# Altium schematic sheet Sheet1.SchDoc
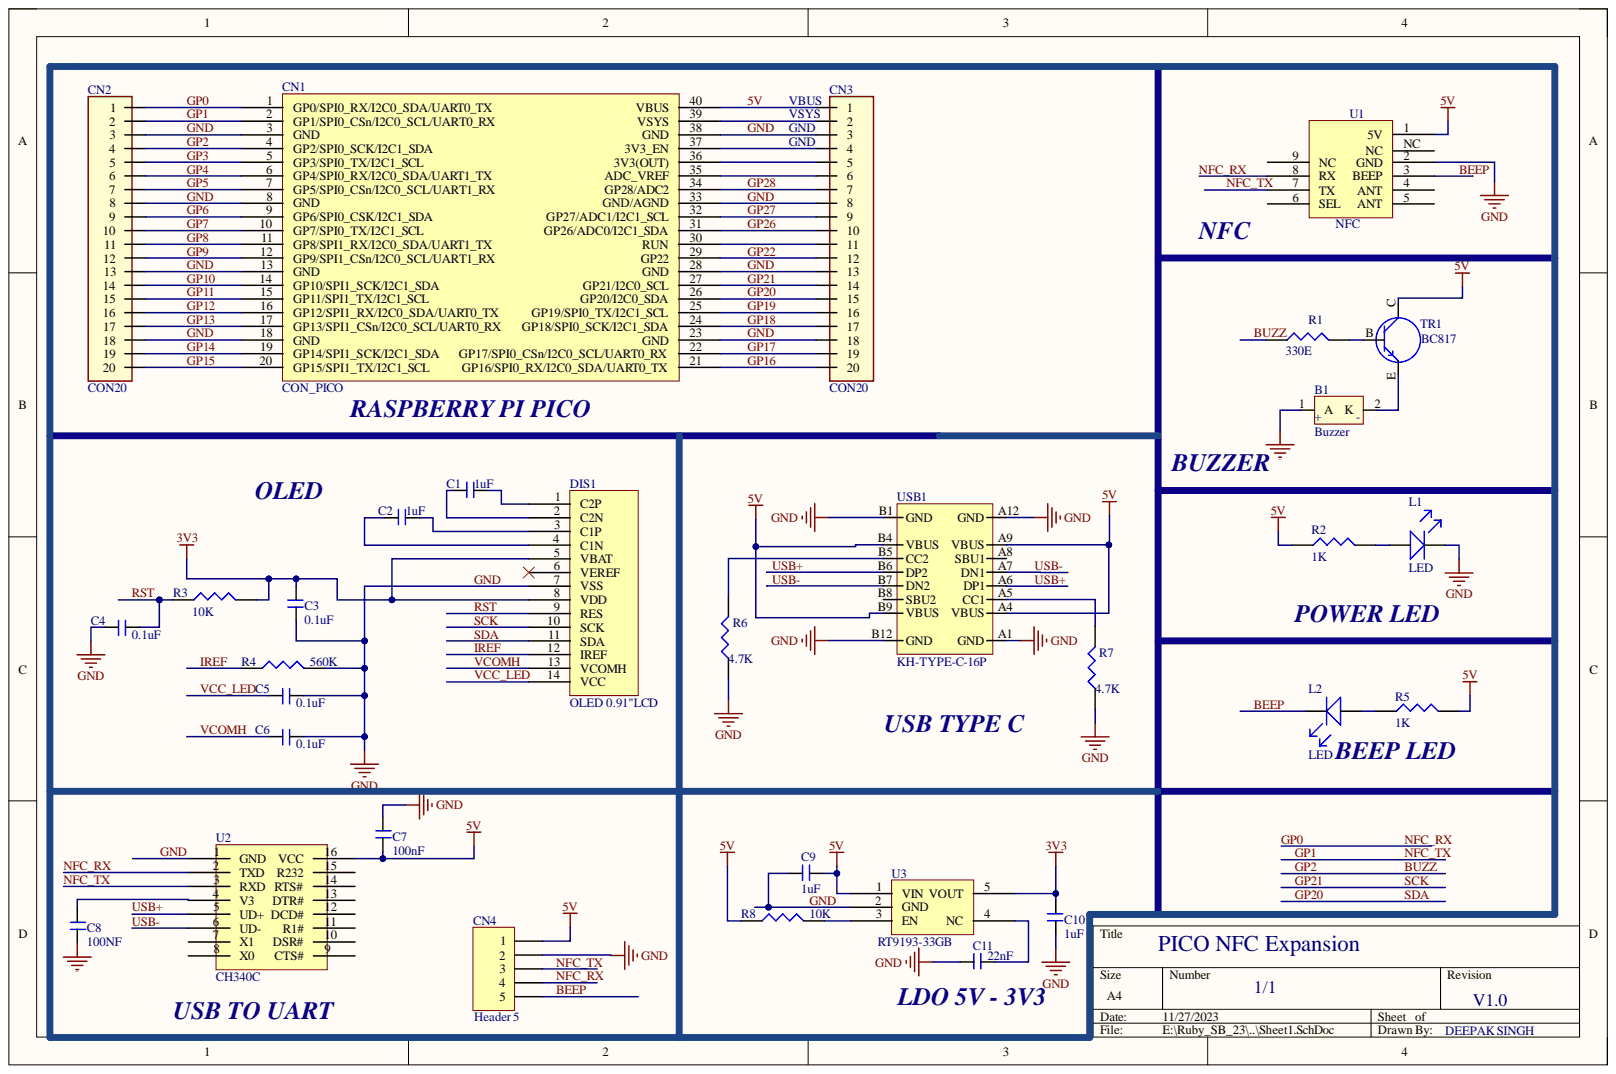
[diagram p0: Sheet1.SchDoc]

## Parts
B1  Buzzer  [BUZZER_9MM]
C1  1uF  [CAP_0603_NONPOLAR]
C2  1uF  [CAP_0603_NONPOLAR]
C3  0.1uF  [CAP_0603_NONPOLAR]
C4  0.1uF  [CAP_0603_NONPOLAR]
C5  0.1uF  [CAP_0603_NONPOLAR]
C6  0.1uF  [CAP_0603_NONPOLAR]
C7  100nF  [C_0603]
C8  100NF  [C_0603]
C9  1uF  [C_0603]
C10  1uF  [C_0603]
C11  22nF  [C_0603]
CN1  CON_PICO  [CON40_PICO_TOP]
CN2  CON20  [CON20_2p5mm]
CN3  CON20  [CON20_2p5mm]
CN4  Header 5  [HDR1X5]
DIS1  OLED 0.91"LCD  [OLED 0.91 LCD]
L1  LED  [LED_0603_neg]
L2  LED  [LED_0603_neg]
R1  330E  [0603]
R2  1K  [0603]
R3  10K  [0603]
R4  560K  [0603]
R5  1K  [0603]
R6  4.7K  [R_0603]
R7  4.7K  [R_0603]
R8  10K  [R_0603]
TR1  BC817  [547_SOT23]
U1  NFC  [RFID]
U2  CH340C  [SOIC_16]
U3  RT9193-33GB  [SOT23-5]
USB1  KH-TYPE-C-16P  [USB TYPE _C]

## Nets
3V3: C3.1 C10.1 DIS1.5(VBAT) DIS1.8(VDD) R3.2 U3.5(VOUT)
5V: C7.1 C9.1 CN1.40(VBUS) CN3.1 CN4.1 R2.1 R5.2 R8.1 TR1.C U1.1(5V) U2.16(VCC) U3.1(VIN) USB1.A4(VBUS) USB1.A9(VBUS) USB1.B4(VBUS) USB1.B9(VBUS)
BEEP: CN4.5 L2.K U1.3(BEEP)
BUZZ: CN1.4(GP2/SPI0_SCK/I2C1_SDA) CN2.4 R1.1
GND: B1.1 C3.2 C4.1 C5.2 C6.2 C7.2 C8.1 C9.2 C10.2 C11.2 CN1.3(GND) CN1.8(GND) CN1.13(GND) CN1.18(GND) CN1.23(GND) CN1.28(GND) CN1.33(GND/AGND) CN1.38(GND) CN2.3 CN2.8 CN2.13 CN2.18 CN3.3 CN3.8 CN3.13 CN3.18 CN4.2 DIS1.7(VSS) L1.K R4.2 R6.1 R7.1 U1.2(GND) U2.1(GND) U3.2(GND) USB1.A1(GND) USB1.A12(GND) USB1.B1(GND) USB1.B12(GND)
GP0: CN1.1(GP0/SPI0_RX/I2C0_SDA/UART0_TX) CN2.1 CN4.4 U1.8(RX) U2.2(TXD)
GP1: CN1.2(GP1/SPI0_CSn/I2C0_SCL/UART0_RX) CN2.2 CN4.3 U1.7(TX) U2.3(RXD)
GP3: CN1.5(GP3/SPI0_TX/I2C1_SCL) CN2.5
GP4: CN1.6(GP4/SPI0_RX/I2C0_SDA/UART1_TX) CN2.6
GP5: CN1.7(GP5/SPI0_CSn/I2C0_SCL/UART1_RX) CN2.7
GP6: CN1.9(GP6/SPI0_CSK/I2C1_SDA) CN2.9
GP7: CN1.10(GP7/SPI0_TX/I2C1_SCL) CN2.10
GP8: CN1.11(GP8/SPI1_RX/I2C0_SDA/UART1_TX) CN2.11
GP9: CN1.12(GP9/SPI1_CSn/I2C0_SCL/UART1_RX) CN2.12
GP10: CN1.14(GP10/SPI1_SCK/I2C1_SDA) CN2.14
GP11: CN1.15(GP11/SPI1_TX/I2C1_SCL) CN2.15
GP12: CN1.16(GP12/SPI1_RX/I2C0_SDA/UART0_TX) CN2.16
GP13: CN1.17(GP13/SPI1_CSn/I2C0_SCL/UART0_RX) CN2.17
GP14: CN1.19(GP14/SPI1_SCK/I2C1_SDA) CN2.19
GP15: CN1.20(GP15/SPI1_TX/I2C1_SCL) CN2.20
GP16: CN1.21(GP16/SPI0_RX/I2C0_SDA/UART0_TX) CN3.20
GP17: CN1.22(GP17/SPI0_CSn/I2C0_SCL/UART0_RX) CN3.19
GP18: CN1.24(GP18/SPI0_SCK/I2C1_SDA) CN3.17
GP19: CN1.25(GP19/SPI0_TX/I2C1_SCL) CN3.16
GP20: CN1.26(GP20/I2C0_SDA) CN3.15 DIS1.11(SDA)
GP21: CN1.27(GP21/I2C0_SCL) CN3.14 DIS1.10(SCK)
GP22: CN1.29(GP22) CN3.12
GP26: CN1.31(GP26/ADC0/I2C1_SDA) CN3.10
GP27: CN1.32(GP27/ADC1/I2C1_SCL) CN3.9
GP28: CN1.34(GP28/ADC2) CN3.7
IREF: DIS1.12(IREF) R4.1
RST: C4.2 DIS1.9(RES) R3.1
USB+: U2.5(UD+) USB1.A6(DP1) USB1.B6(DP2)
USB-: U2.6(UD-) USB1.A7(DN1) USB1.B7(DN2)
VCC_LED: C5.1 DIS1.14(VCC)
VCOMH: C6.1 DIS1.13(VCOMH)
NetB1_2: B1.2 TR1.E
NetC1_1: C1.1 DIS1.1(C2P)
NetC1_2: C1.2 DIS1.2(C2N)
NetC2_1: C2.1 DIS1.3(C1P)
NetC2_2: C2.2 DIS1.4(C1N)
NetC8_2: C8.2 U2.4(V3)
NetC11_1: C11.1 U3.4(NC)
NetCN1_30: CN1.30(RUN) CN3.11
NetCN1_35: CN1.35(ADC_VREF) CN3.6
NetCN1_36: CN1.36(3V3(OUT)) CN3.5
NetCN1_37: CN1.37(3V3_EN) CN3.4
NetCN1_39: CN1.39(VSYS) CN3.2
NetL1_A: L1.A R2.2
NetL2_A: L2.A R5.1
NetR1_2: R1.2 TR1.B
NetR6_2: R6.2 USB1.B5(CC2)
NetR7_2: R7.2 USB1.A5(CC1)
NetR8_2: R8.2 U3.3(EN)

Not connected: DIS1 6(VEREF); U1 4(ANT) 5(ANT) 6(SEL) 9(NC) NC; U2 7(X1) 8(X0) 9(CTS#) 10(DSR#) 11(R1#) 12(DCD#) 13(DTR#) 14(RTS#) 15(R232); USB1 A8(SBU1) B8(SBU2)

## Library data of the parts
C1-C11 = CAP_NONPOLAR: Component Kind: Standard; Library Name: dxp_pasl_lib.IntLib
CN1 = CON40_PICO: Component Kind: Standard; Library Name: dxp_pasl_lib.IntLib
CN4 = Header 5: Header, 5-Pin; Component Kind: Standard; LatestRevisionDate: 17-Jul-2002; LatestRevisionNote: Re-released for DXP Platform.; Library Name: Miscellaneous Connectors.IntLib; Signal Integrity: Connector; Publisher: Altium Limited
DIS1 = OLED 0.91"LCD: OLED I2C Display, 128x32 I2C OLED Display; Component Kind: Standard; Library Name: dxp_pasl_lib.IntLib
R1-R8 = RES: Component Kind: Standard; Library Name: dxp_pasl_lib.IntLib
TR1 = TRANSISTER_NPN: Component Kind: Standard; Library Name: dxp_pasl_lib.IntLib
U1 = NFC: 8MHz XO (Standard) CMOS Oscillator 3.3V Standby (Power Down) 4-SMD, No Lead; Component Kind: Standard; Library Name: MIX.SchLib
U3 = AP2112: 600mA CMOS LDO REGULATOR WITH ENABLE SOT-23-5 PACKAGE; Component Kind: Standard; Library Name: dxp_pasl_lib.IntLib
USB1 = USB TYPE-C KH-TYPE-C-16P: 3A 1 Surface Mount 16 Female -40?~+85? Type-C SMD USB Connectors ROHS #  C709357; Component Kind: Standard; ComponentLink2Description: New link; ComponentLink2URL: https://lcsc.com/product-detail/USB-Connectors_Shenzhen-Kinghelm-Elec-KH-TYPE-C-16P_C709357.html; Supplier 1: LCSC; Supplier Part Number 1: C165948; Library Name: dxp_pasl_lib.IntLib
B1 = Buzzer: Component Kind: Standard; Library Name: dxp_pasl_lib.IntLib
CN2 CN3 = CON20: Component Kind: Standard; Library Name: dxp_pasl_lib.IntLib
L1 L2 = LED: Component Kind: Standard; Library Name: dxp_pasl_lib.IntLib
U2 = CH340C: Component Kind: Standard; Library Name: dxp_pasl_lib.IntLib
